# Altium schematic sheet MPU6500.SchDoc(MPU6500)
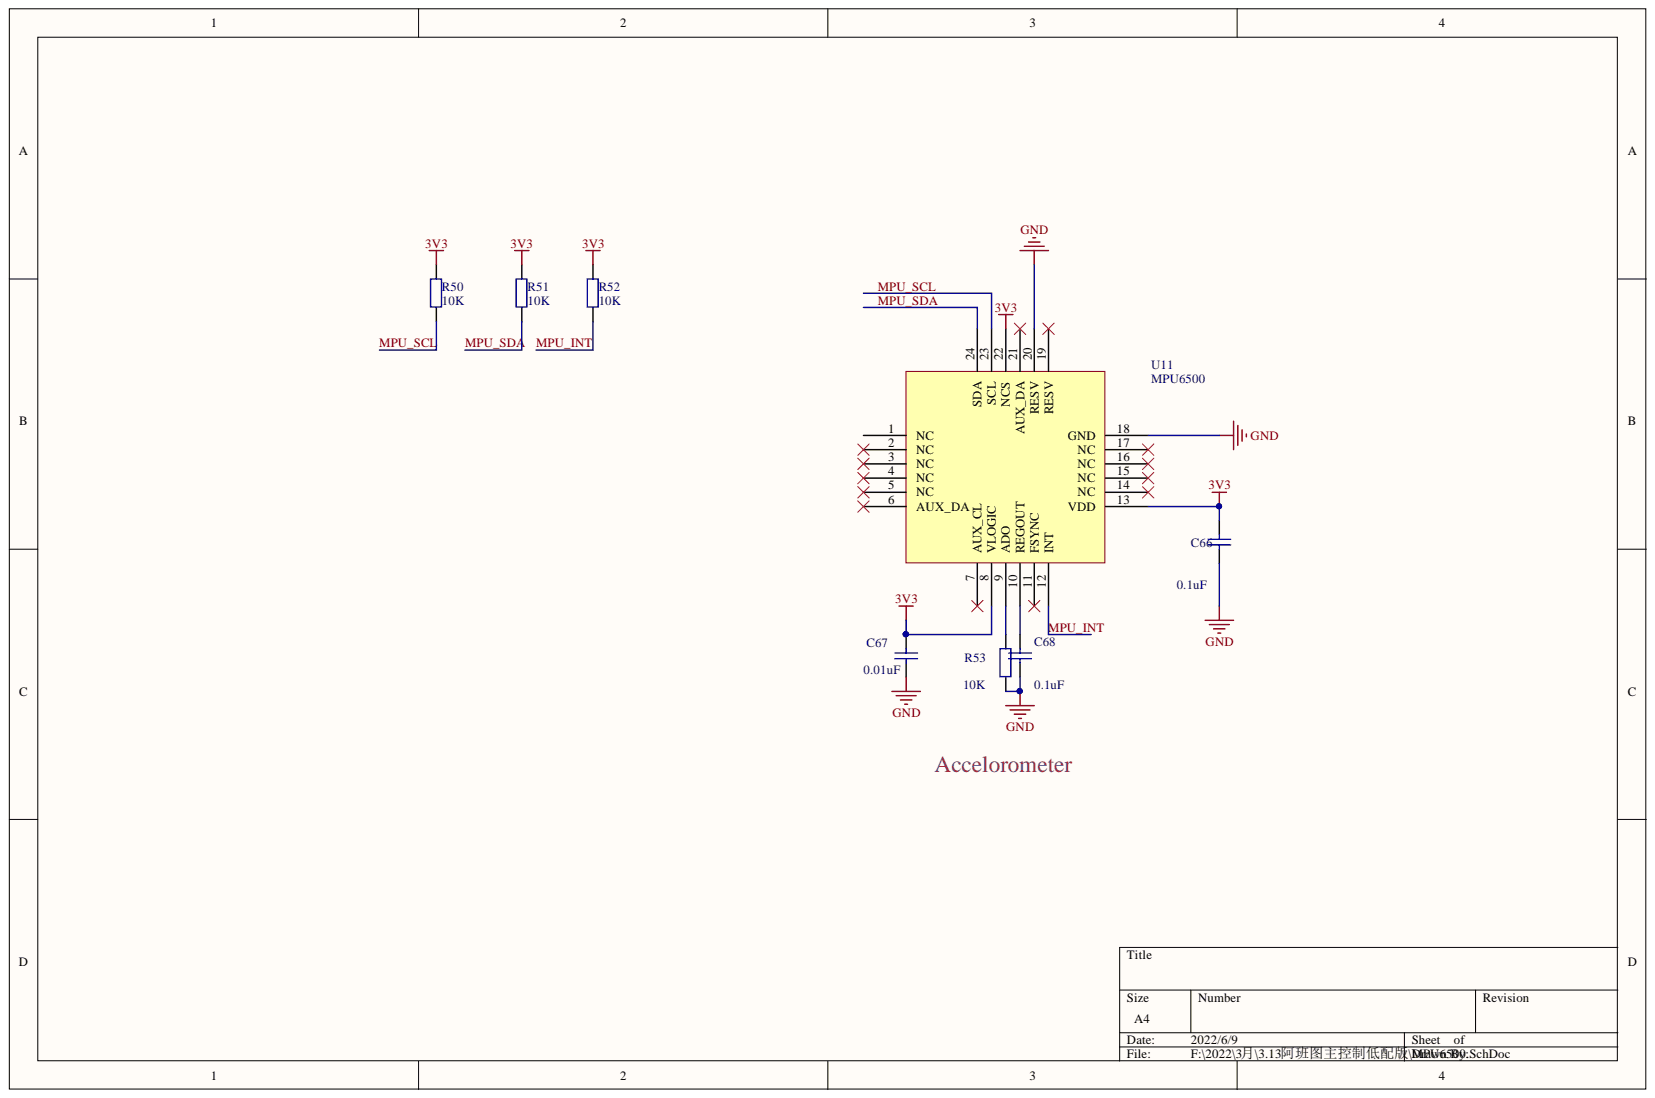
[diagram p0: MPU6500.SchDoc]

## Parts
C66  0.1uF  [C0603]
C67  0.01uF  [C0603]
C68  0.1uF  [C0603]
R50  10K  [R0603]
R51  10K  [R0603]
R52  10K  [R0603]
R53  10K  [R0603]
U11  MPU6500  [QFN24_3X3]

## Nets
3V3: C66.1 C67.1 R50.2 R51.2 R52.2 U11.8(VLOGIC) U11.13(VDD) U11.22(NCS)
GND: C66.2 C67.2 C68.2 R53.2 U11.18(GND) U11.20(RESV)
MPU_INT: R52.1 U11.12(INT)
MPU_SCL: R50.1 U11.23(SCL)
MPU_SDA: R51.1 U11.24(SDA)
NetC68_1: C68.1 U11.10(REGOUT)
NetR53_1: R53.1 U11.9(ADO)

Not connected: U11 1(NC) 2(NC) 3(NC) 4(NC) 5(NC) 6(AUX_DA) 7(AUX_CL) 11(FSYNC) 14(NC) 15(NC) 16(NC) 17(NC) 19(RESV) 21(AUX_DA)

## Library data of the parts
C66-C68 = Cap: Capacitor; Component Kind: Standard; LatestRevisionDate: 17-Jul-2002; LatestRevisionNote: Re-released for DXP Platform.; Library Name: Miscellaneous Devices.IntLib; PackageDescription: Radial Cap, Thru-Hole; 2 Leads; 0.3 in Pin Spacing; PackageReference: 0603C; Published: 8-Jun-2000; Signal Integrity: Cap; Simulation: CAP; Publisher: Altium Limited
  C66 C68: Value: 0.1uF
  C67: Value: 0.01uF
R50-R53 = Res2: Resistor; Component Kind: Standard; LatestRevisionDate: 17-Jul-2002; LatestRevisionNote: Re-released for DXP Platform.; Library Name: Miscellaneous Devices.IntLib; PackageDescription: Axial Device, Thru-Hole; 2 Leads; 0.4 in Pin Spacing; PackageReference: 0603R; Published: 8-Jun-2000; Signal Integrity: Res; Simulation: RESISTOR; Value: 10K; Publisher: Altium Limited
U11 = MPU6050: Component Kind: Standard; Library Name: PCB_Project_1.SCHLIB
